# Altium schematic sheet Mainboard.SchDoc
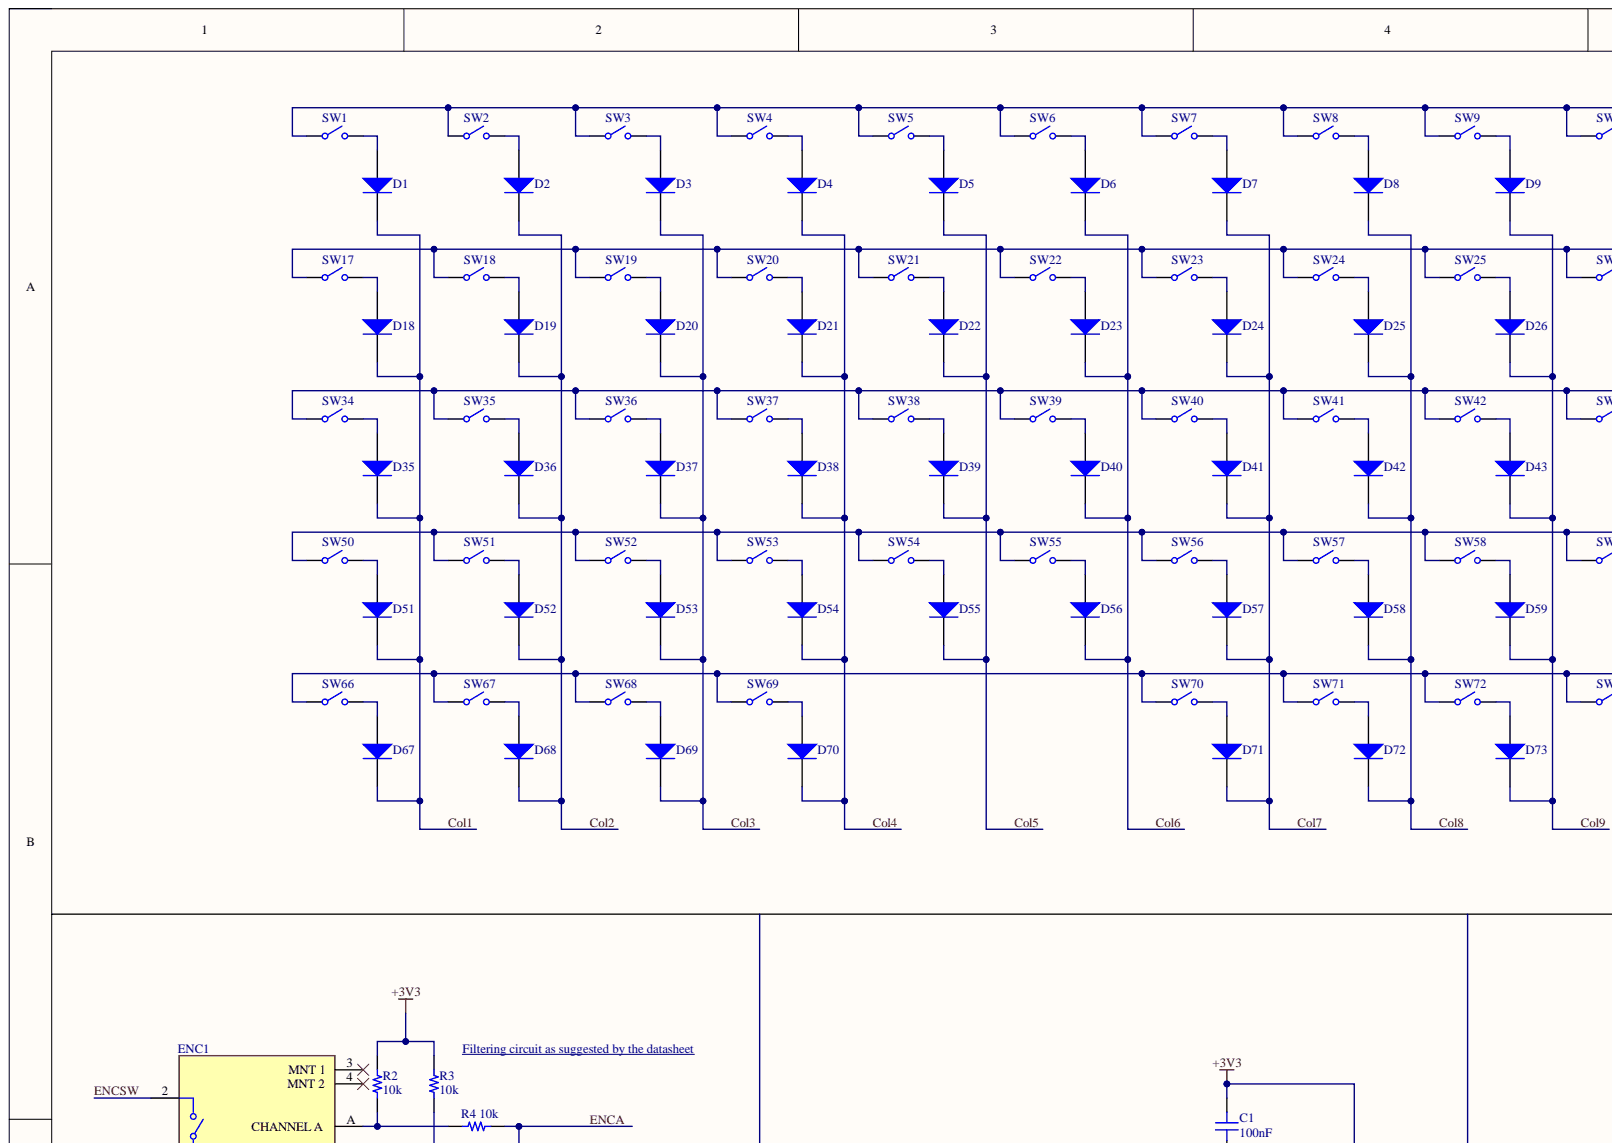
[diagram p0: Mainboard.SchDoc, top left]
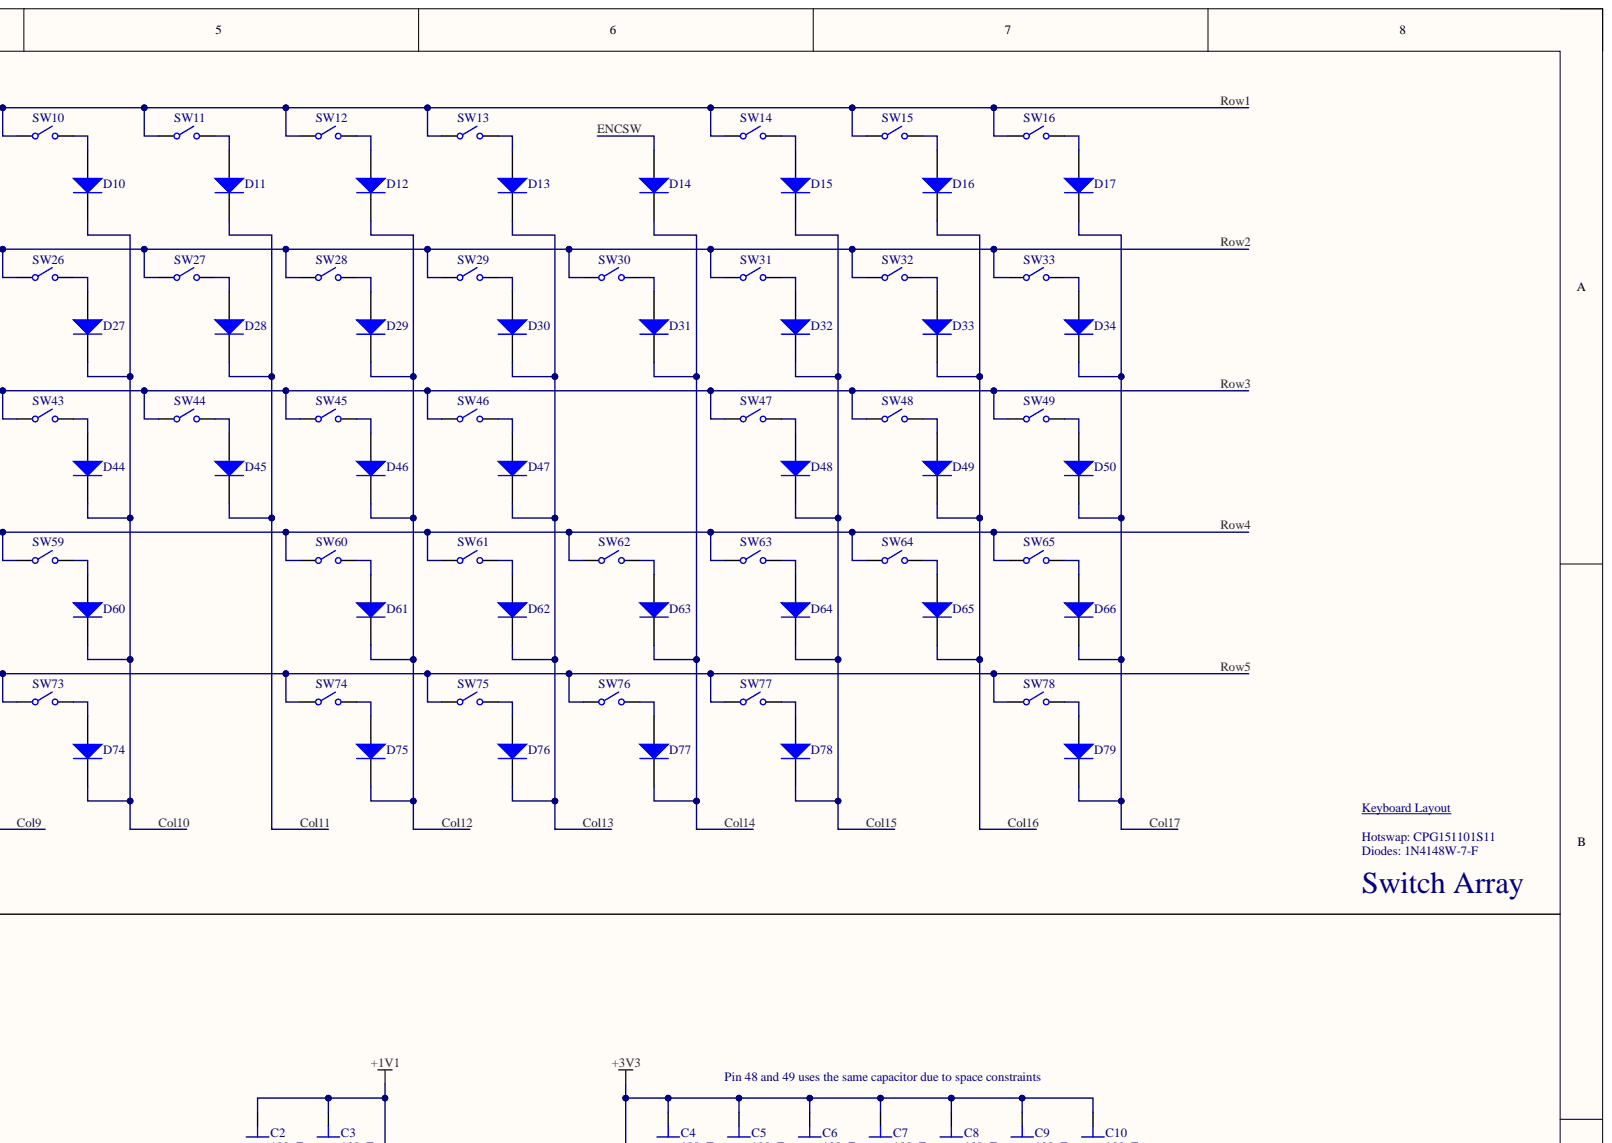
[diagram p1: Mainboard.SchDoc, top right]
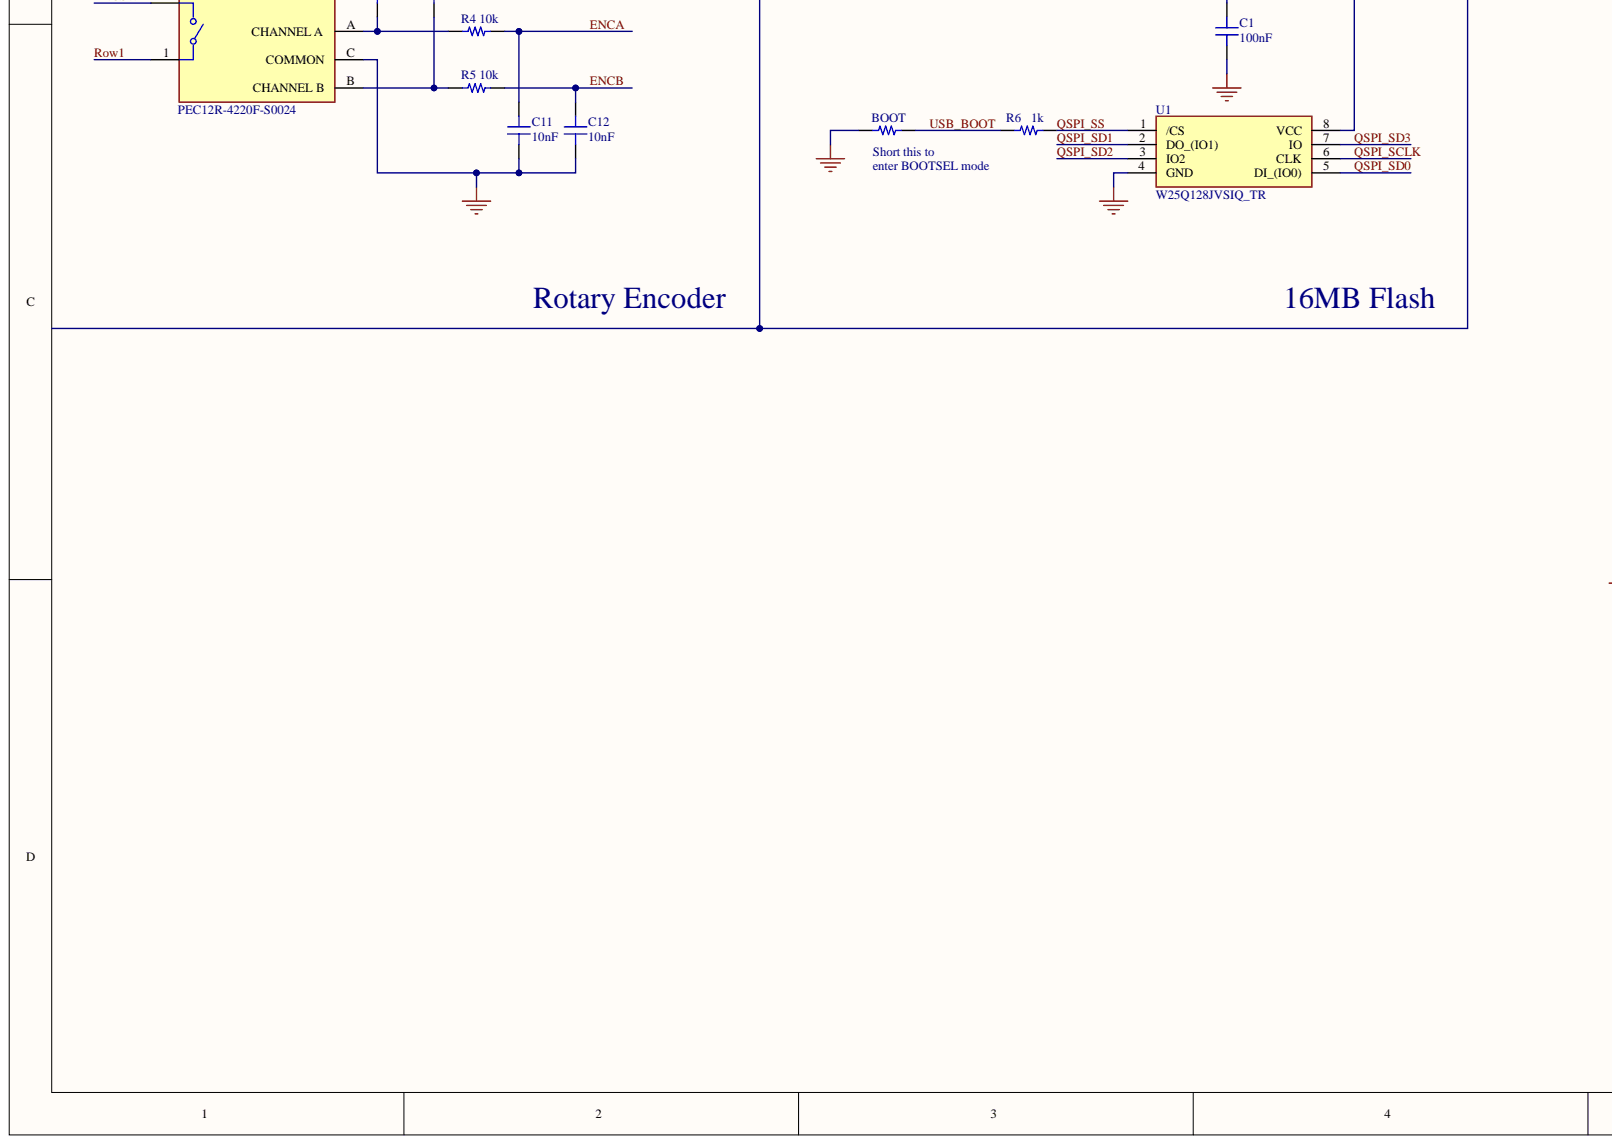
[diagram p2: Mainboard.SchDoc, bottom left]
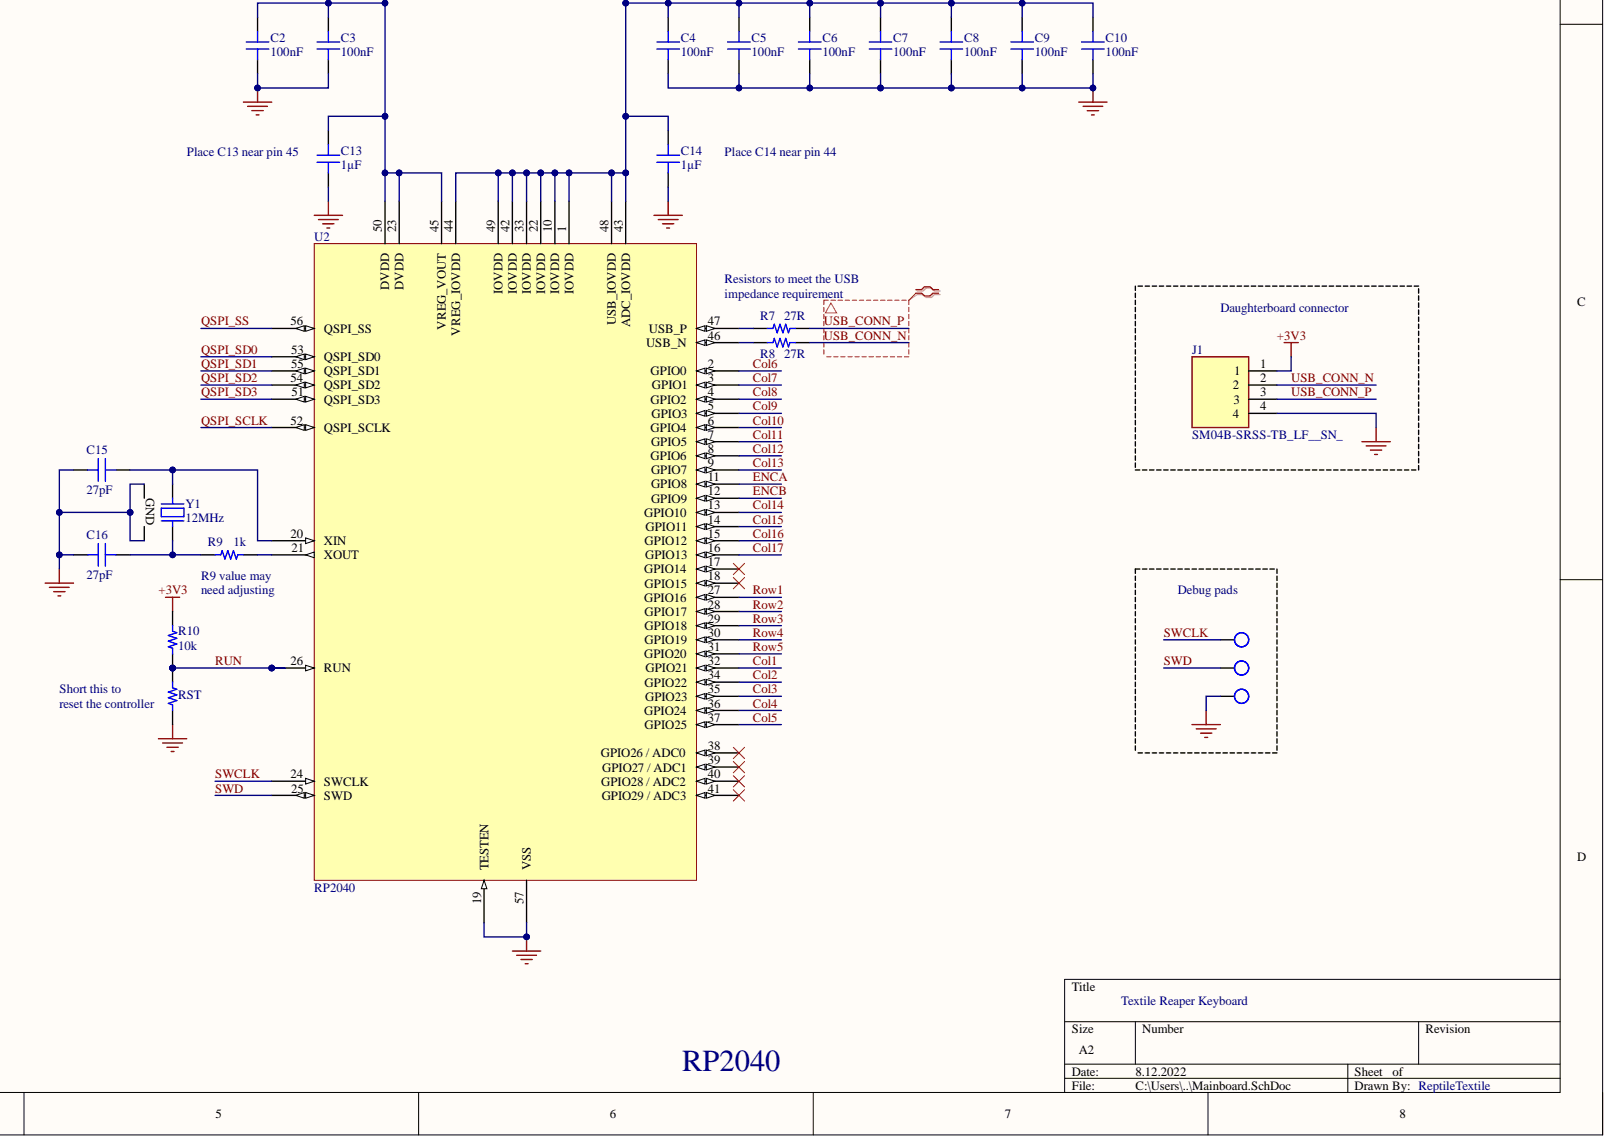
[diagram p3: Mainboard.SchDoc, bottom right]

## Parts
BOOT  0  [RES 0402_1005]  (value not drawn)
C1  100nF  [CAP 0402_1005]
C2  100nF  [CAP 0402_1005]
C3  100nF  [CAP 0402_1005]
C4  100nF  [CAP 0402_1005]
C5  100nF  [CAP 0402_1005]
C6  100nF  [CAP 0402_1005]
C7  100nF  [CAP 0402_1005]
C8  100nF  [CAP 0402_1005]
C9  100nF  [CAP 0402_1005]
C10  100nF  [CAP 0402_1005]
C11  10nF  [CAP 0402_1005]
C12  10nF  [CAP 0402_1005]
C13  1µF  [CAP 0402_1005]
C14  1µF  [CAP 0402_1005]
C15  27pF  [CAP 0402_1005]
C16  27pF  [CAP 0402_1005]
D1  1N4148W-7-F  [DIOD-SOD123-2_V]
D2  1N4148W-7-F  [DIOD-SOD123-2_V]
D3  1N4148W-7-F  [DIOD-SOD123-2_V]
D4  1N4148W-7-F  [DIOD-SOD123-2_V]
D5  1N4148W-7-F  [DIOD-SOD123-2_V]
D6  1N4148W-7-F  [DIOD-SOD123-2_V]
D7  1N4148W-7-F  [DIOD-SOD123-2_V]
D8  1N4148W-7-F  [DIOD-SOD123-2_V]
D9  1N4148W-7-F  [DIOD-SOD123-2_V]
D10  1N4148W-7-F  [DIOD-SOD123-2_V]
D11  1N4148W-7-F  [DIOD-SOD123-2_V]
D12  1N4148W-7-F  [DIOD-SOD123-2_V]
D13  1N4148W-7-F  [DIOD-SOD123-2_V]
D14  1N4148W-7-F  [DIOD-SOD123-2_V]
D15  1N4148W-7-F  [DIOD-SOD123-2_V]
D16  1N4148W-7-F  [DIOD-SOD123-2_V]
D17  1N4148W-7-F  [DIOD-SOD123-2_V]
D18  1N4148W-7-F  [DIOD-SOD123-2_V]
D19  1N4148W-7-F  [DIOD-SOD123-2_V]
D20  1N4148W-7-F  [DIOD-SOD123-2_V]
D21  1N4148W-7-F  [DIOD-SOD123-2_V]
D22  1N4148W-7-F  [DIOD-SOD123-2_V]
D23  1N4148W-7-F  [DIOD-SOD123-2_V]
D24  1N4148W-7-F  [DIOD-SOD123-2_V]
D25  1N4148W-7-F  [DIOD-SOD123-2_V]
D26  1N4148W-7-F  [DIOD-SOD123-2_V]
D27  1N4148W-7-F  [DIOD-SOD123-2_V]
D28  1N4148W-7-F  [DIOD-SOD123-2_V]
D29  1N4148W-7-F  [DIOD-SOD123-2_V]
D30  1N4148W-7-F  [DIOD-SOD123-2_V]
D31  1N4148W-7-F  [DIOD-SOD123-2_V]
D32  1N4148W-7-F  [DIOD-SOD123-2_V]
D33  1N4148W-7-F  [DIOD-SOD123-2_V]
D34  1N4148W-7-F  [DIOD-SOD123-2_V]
D35  1N4148W-7-F  [DIOD-SOD123-2_V]
D36  1N4148W-7-F  [DIOD-SOD123-2_V]
D37  1N4148W-7-F  [DIOD-SOD123-2_V]
D38  1N4148W-7-F  [DIOD-SOD123-2_V]
D39  1N4148W-7-F  [DIOD-SOD123-2_V]
D40  1N4148W-7-F  [DIOD-SOD123-2_V]
D41  1N4148W-7-F  [DIOD-SOD123-2_V]
D42  1N4148W-7-F  [DIOD-SOD123-2_V]
D43  1N4148W-7-F  [DIOD-SOD123-2_V]
D44  1N4148W-7-F  [DIOD-SOD123-2_V]
D45  1N4148W-7-F  [DIOD-SOD123-2_V]
D46  1N4148W-7-F  [DIOD-SOD123-2_V]
D47  1N4148W-7-F  [DIOD-SOD123-2_V]
D48  1N4148W-7-F  [DIOD-SOD123-2_V]
D49  1N4148W-7-F  [DIOD-SOD123-2_V]
D50  1N4148W-7-F  [DIOD-SOD123-2_V]
D51  1N4148W-7-F  [DIOD-SOD123-2_V]
D52  1N4148W-7-F  [DIOD-SOD123-2_V]
D53  1N4148W-7-F  [DIOD-SOD123-2_V]
D54  1N4148W-7-F  [DIOD-SOD123-2_V]
D55  1N4148W-7-F  [DIOD-SOD123-2_V]
D56  1N4148W-7-F  [DIOD-SOD123-2_V]
D57  1N4148W-7-F  [DIOD-SOD123-2_V]
D58  1N4148W-7-F  [DIOD-SOD123-2_V]
D59  1N4148W-7-F  [DIOD-SOD123-2_V]
D60  1N4148W-7-F  [DIOD-SOD123-2_V]
D61  1N4148W-7-F  [DIOD-SOD123-2_V]
D62  1N4148W-7-F  [DIOD-SOD123-2_V]
D63  1N4148W-7-F  [DIOD-SOD123-2_V]
D64  1N4148W-7-F  [DIOD-SOD123-2_V]
D65  1N4148W-7-F  [DIOD-SOD123-2_V]
D66  1N4148W-7-F  [DIOD-SOD123-2_V]
D67  1N4148W-7-F  [DIOD-SOD123-2_V]
D68  1N4148W-7-F  [DIOD-SOD123-2_V]
D69  1N4148W-7-F  [DIOD-SOD123-2_V]
D70  1N4148W-7-F  [DIOD-SOD123-2_V]
D71  1N4148W-7-F  [DIOD-SOD123-2_V]
D72  1N4148W-7-F  [DIOD-SOD123-2_V]
D73  1N4148W-7-F  [DIOD-SOD123-2_V]
D74  1N4148W-7-F  [DIOD-SOD123-2_V]
D75  1N4148W-7-F  [DIOD-SOD123-2_V]
D76  1N4148W-7-F  [DIOD-SOD123-2_V]
D77  1N4148W-7-F  [DIOD-SOD123-2_V]
D78  1N4148W-7-F  [DIOD-SOD123-2_V]
D79  1N4148W-7-F  [DIOD-SOD123-2_V]
ENC1  PEC12R-4220F-S0024  [FP-PEC12R-4220F-S0024-MFG]
GND  Pad  [Square_Pad]  (value not drawn)
J1  SM04B-SRSS-TB_LF__SN_  [SM04B-SRSS-TB(LFSN)]
R2  10k  [RES 0402_1005]
R3  10k  [RES 0402_1005]
R4  10k  [RES 0402_1005]
R5  10k  [RES 0402_1005]
R6  1k  [RES 0402_1005]
R7  27R  [RES 0402_1005]
R8  27R  [RES 0402_1005]
R9  1k  [RES 0402_1005]
R10  10k  [RES 0402_1005]
RST  0  [RES 0402_1005]  (value not drawn)
SW1  CPG151101S11  [CPG151101S11]
SW2  CPG151101S11  [CPG151101S11]
SW3  CPG151101S11  [CPG151101S11]
SW4  CPG151101S11  [CPG151101S11]
SW5  CPG151101S11  [CPG151101S11]
SW6  CPG151101S11  [CPG151101S11]
SW7  CPG151101S11  [CPG151101S11]
SW8  CPG151101S11  [CPG151101S11]
SW9  CPG151101S11  [CPG151101S11]
SW10  CPG151101S11  [CPG151101S11]
SW11  CPG151101S11  [CPG151101S11]
SW12  CPG151101S11  [CPG151101S11]
SW13  CPG151101S11  [CPG151101S11]
SW14  CPG151101S11  [CPG151101S11]
SW15  CPG151101S11  [CPG151101S11]
SW16  CPG151101S11  [CPG151101S11]
SW17  CPG151101S11  [CPG151101S11]
SW18  CPG151101S11  [CPG151101S11]
SW19  CPG151101S11  [CPG151101S11]
SW20  CPG151101S11  [CPG151101S11]
SW21  CPG151101S11  [CPG151101S11]
SW22  CPG151101S11  [CPG151101S11]
SW23  CPG151101S11  [CPG151101S11]
SW24  CPG151101S11  [CPG151101S11]
SW25  CPG151101S11  [CPG151101S11]
SW26  CPG151101S11  [CPG151101S11]
SW27  CPG151101S11  [CPG151101S11]
SW28  CPG151101S11  [CPG151101S11]
SW29  CPG151101S11  [CPG151101S11]
SW30  CPG151101S11  [CPG151101S11]
SW31  CPG151101S11  [CPG151101S11]
SW32  CPG151101S11  [CPG151101S11]
SW33  CPG151101S11  [CPG151101S11]
SW34  CPG151101S11  [CPG151101S11]
SW35  CPG151101S11  [CPG151101S11]
SW36  CPG151101S11  [CPG151101S11]
SW37  CPG151101S11  [CPG151101S11]
SW38  CPG151101S11  [CPG151101S11]
SW39  CPG151101S11  [CPG151101S11]
SW40  CPG151101S11  [CPG151101S11]
SW41  CPG151101S11  [CPG151101S11]
SW42  CPG151101S11  [CPG151101S11]
SW43  CPG151101S11  [CPG151101S11]
SW44  CPG151101S11  [CPG151101S11]
SW45  CPG151101S11  [CPG151101S11]
SW46  CPG151101S11  [CPG151101S11]
SW47  CPG151101S11  [CPG151101S11]
SW48  CPG151101S11  [CPG151101S11]
SW49  CPG151101S11  [CPG151101S11]
SW50  CPG151101S11  [CPG151101S11]
SW51  CPG151101S11  [CPG151101S11]
SW52  CPG151101S11  [CPG151101S11]
SW53  CPG151101S11  [CPG151101S11]
SW54  CPG151101S11  [CPG151101S11]
SW55  CPG151101S11  [CPG151101S11]
SW56  CPG151101S11  [CPG151101S11]
SW57  CPG151101S11  [CPG151101S11]
SW58  CPG151101S11  [CPG151101S11]
SW59  CPG151101S11  [CPG151101S11]
SW60  CPG151101S11  [CPG151101S11]
SW61  CPG151101S11  [CPG151101S11]
SW62  CPG151101S11  [CPG151101S11]
SW63  CPG151101S11  [CPG151101S11]
SW64  CPG151101S11  [CPG151101S11]
SW65  CPG151101S11  [CPG151101S11]
SW66  CPG151101S11  [CPG151101S11]
SW67  CPG151101S11  [CPG151101S11]
SW68  CPG151101S11  [CPG151101S11]
SW69  CPG151101S11  [CPG151101S11]
SW70  CPG151101S11  [CPG151101S11]
SW71  CPG151101S11  [CPG151101S11]
SW72  CPG151101S11  [CPG151101S11]
SW73  CPG151101S11  [CPG151101S11]
SW74  CPG151101S11  [CPG151101S11]
SW75  CPG151101S11  [CPG151101S11]
SW76  CPG151101S11  [CPG151101S11]
SW77  CPG151101S11  [CPG151101S11]
SW78  CPG151101S11  [CPG151101S11]
SWCLK  Pad  [Square_Pad]  (value not drawn)
SWD  Pad  [Square_Pad]  (value not drawn)
U1  W25Q128JVSIQ_TR  [SOIC127P780X195-8N]
U2  RP2040  [RP2040_QFN56]
Y1  12MHz  [ABRACON ABM8G]

## Nets
+1V1: C2.2 C3.2 C13.2 U2.23(DVDD) U2.45(VREG_VOUT) U2.50(DVDD)
+3V3: C1.2 C4.2 C5.2 C6.2 C7.2 C8.2 C9.2 C10.2 C14.2 J1.1 R2.1 R3.1 R10.1 U1.8(VCC) U2.1(IOVDD) U2.10(IOVDD) U2.22(IOVDD) U2.33(IOVDD) U2.42(IOVDD) U2.43(ADC_IOVDD) U2.44(VREG_IOVDD) U2.48(USB_IOVDD) U2.49(IOVDD)
GND: BOOT.1 C1.1 C2.1 C3.1 C4.1 C5.1 C6.1 C7.1 C8.1 C9.1 C10.1 C11.1 C12.1 C13.1 C14.1 C15.1 C16.1 ENC1.C(COMMON) GND.0 J1.4 RST.1 U1.4(GND) U2.19(TESTEN) U2.57(VSS) Y1.2 Y1.4
Col1: D1.2 D18.2 D35.2 D51.2 D67.2 U2.32(GPIO21)
Col2: D2.2 D19.2 D36.2 D52.2 D68.2 U2.34(GPIO22)
Col3: D3.2 D20.2 D37.2 D53.2 D69.2 U2.35(GPIO23)
Col4: D4.2 D21.2 D38.2 D54.2 D70.2 U2.36(GPIO24)
Col5: D5.2 D22.2 D39.2 D55.2 U2.37(GPIO25)
Col6: D6.2 D23.2 D40.2 D56.2 U2.2(GPIO0)
Col7: D7.2 D24.2 D41.2 D57.2 D71.2 U2.3(GPIO1)
Col8: D8.2 D25.2 D42.2 D58.2 D72.2 U2.4(GPIO2)
Col9: D9.2 D26.2 D43.2 D59.2 D73.2 U2.5(GPIO3)
Col10: D10.2 D27.2 D44.2 D60.2 D74.2 U2.6(GPIO4)
Col11: D11.2 D28.2 D45.2 U2.7(GPIO5)
Col12: D12.2 D29.2 D46.2 D61.2 D75.2 U2.8(GPIO6)
Col13: D13.2 D30.2 D47.2 D62.2 D76.2 U2.9(GPIO7)
Col14: D14.2 D31.2 D63.2 D77.2 U2.13(GPIO10)
Col15: D15.2 D32.2 D48.2 D64.2 D78.2 U2.14(GPIO11)
Col16: D16.2 D33.2 D49.2 D65.2 U2.15(GPIO12)
Col17: D17.2 D34.2 D50.2 D66.2 D79.2 U2.16(GPIO13)
ENCA: C11.2 R4.2 U2.11(GPIO8)
ENCB: C12.2 R5.2 U2.12(GPIO9)
ENCSW: D14.1 ENC1.2
QSPI_SCLK: U1.6(CLK) U2.52(QSPI_SCLK)
QSPI_SD0: U1.5(DI_(IO0)) U2.53(QSPI_SD0)
QSPI_SD1: U1.2(DO_(IO1)) U2.55(QSPI_SD1)
QSPI_SD2: U1.3(IO2) U2.54(QSPI_SD2)
QSPI_SD3: U1.7(IO) U2.51(QSPI_SD3)
QSPI_SS: R6.2 U1.1(/CS) U2.56(QSPI_SS)
Row1: ENC1.1 SW1.1 SW2.1 SW3.1 SW4.1 SW5.1 SW6.1 SW7.1 SW8.1 SW9.1 SW10.1 SW11.1 SW12.1 SW13.1 SW14.1 SW15.1 SW16.1 U2.27(GPIO16)
Row2: SW17.1 SW18.1 SW19.1 SW20.1 SW21.1 SW22.1 SW23.1 SW24.1 SW25.1 SW26.1 SW27.1 SW28.1 SW29.1 SW30.1 SW31.1 SW32.1 SW33.1 U2.28(GPIO17)
Row3: SW34.1 SW35.1 SW36.1 SW37.1 SW38.1 SW39.1 SW40.1 SW41.1 SW42.1 SW43.1 SW44.1 SW45.1 SW46.1 SW47.1 SW48.1 SW49.1 U2.29(GPIO18)
Row4: SW50.1 SW51.1 SW52.1 SW53.1 SW54.1 SW55.1 SW56.1 SW57.1 SW58.1 SW59.1 SW60.1 SW61.1 SW62.1 SW63.1 SW64.1 SW65.1 U2.30(GPIO19)
Row5: SW66.1 SW67.1 SW68.1 SW69.1 SW70.1 SW71.1 SW72.1 SW73.1 SW74.1 SW75.1 SW76.1 SW77.1 SW78.1 U2.31(GPIO20)
RUN: R10.2 RST.2 U2.26(RUN)
SWCLK: SWCLK.0 U2.24(SWCLK)
SWD: SWD.0 U2.25(SWD)
USB_BOOT: BOOT.2 R6.1
USB_CONN_N: J1.2 R8.2
USB_CONN_P: J1.3 R7.2
NetC15_2: C15.2 U2.20(XIN) Y1.1
NetC16_2: C16.2 R9.1 Y1.3
NetD1_1: D1.1 SW1.2
NetD2_1: D2.1 SW2.2
NetD3_1: D3.1 SW3.2
NetD4_1: D4.1 SW4.2
NetD5_1: D5.1 SW5.2
NetD6_1: D6.1 SW6.2
NetD7_1: D7.1 SW7.2
NetD8_1: D8.1 SW8.2
NetD9_1: D9.1 SW9.2
NetD10_1: D10.1 SW10.2
NetD11_1: D11.1 SW11.2
NetD12_1: D12.1 SW12.2
NetD13_1: D13.1 SW13.2
NetD15_1: D15.1 SW14.2
NetD16_1: D16.1 SW15.2
NetD17_1: D17.1 SW16.2
NetD18_1: D18.1 SW17.2
NetD19_1: D19.1 SW18.2
NetD20_1: D20.1 SW19.2
NetD21_1: D21.1 SW20.2
NetD22_1: D22.1 SW21.2
NetD23_1: D23.1 SW22.2
NetD24_1: D24.1 SW23.2
NetD25_1: D25.1 SW24.2
NetD26_1: D26.1 SW25.2
NetD27_1: D27.1 SW26.2
NetD28_1: D28.1 SW27.2
NetD29_1: D29.1 SW28.2
NetD30_1: D30.1 SW29.2
NetD31_1: D31.1 SW30.2
NetD32_1: D32.1 SW31.2
NetD33_1: D33.1 SW32.2
NetD34_1: D34.1 SW33.2
NetD35_1: D35.1 SW34.2
NetD36_1: D36.1 SW35.2
NetD37_1: D37.1 SW36.2
NetD38_1: D38.1 SW37.2
NetD39_1: D39.1 SW38.2
NetD40_1: D40.1 SW39.2
NetD41_1: D41.1 SW40.2
NetD42_1: D42.1 SW41.2
NetD43_1: D43.1 SW42.2
NetD44_1: D44.1 SW43.2
NetD45_1: D45.1 SW44.2
NetD46_1: D46.1 SW45.2
NetD47_1: D47.1 SW46.2
NetD48_1: D48.1 SW47.2
NetD49_1: D49.1 SW48.2
NetD50_1: D50.1 SW49.2
NetD51_1: D51.1 SW50.2
NetD52_1: D52.1 SW51.2
NetD53_1: D53.1 SW52.2
NetD54_1: D54.1 SW53.2
NetD55_1: D55.1 SW54.2
NetD56_1: D56.1 SW55.2
NetD57_1: D57.1 SW56.2
NetD58_1: D58.1 SW57.2
NetD59_1: D59.1 SW58.2
NetD60_1: D60.1 SW59.2
NetD61_1: D61.1 SW60.2
NetD62_1: D62.1 SW61.2
NetD63_1: D63.1 SW62.2
NetD64_1: D64.1 SW63.2
NetD65_1: D65.1 SW64.2
NetD66_1: D66.1 SW65.2
NetD67_1: D67.1 SW66.2
NetD68_1: D68.1 SW67.2
NetD69_1: D69.1 SW68.2
NetD70_1: D70.1 SW69.2
NetD71_1: D71.1 SW70.2
NetD72_1: D72.1 SW71.2
NetD73_1: D73.1 SW72.2
NetD74_1: D74.1 SW73.2
NetD75_1: D75.1 SW74.2
NetD76_1: D76.1 SW75.2
NetD77_1: D77.1 SW76.2
NetD78_1: D78.1 SW77.2
NetD79_1: D79.1 SW78.2
NetENC1_A: ENC1.A(CHANNEL_A) R2.2 R4.1
NetENC1_B: ENC1.B(CHANNEL_B) R3.2 R5.1
NetR7_1: R7.1 U2.47(USB_P)
NetR8_1: R8.1 U2.46(USB_N)
NetR9_2: R9.2 U2.21(XOUT)

Not connected: ENC1 3(MNT_1) 4(MNT_2); U2 17(GPIO14) 18(GPIO15) 38(GPIO26_/_ADC0) 39(GPIO27_/_ADC1) 40(GPIO28_/_ADC2) 41(GPIO29_/_ADC3)

## Library data of the parts
BOOT RST = CR0402AJ/-000GAS: RESA-AS 0402 0R JUMPER 63MW; Manufacturer: Bourns Inc.; Database Table Name: Resistors - Surface Mount - 0402; Power (Watts): 0.063W, 1/16W; Resistance: 0 Ohms; Component Kind: Standard; ComponentLink1Description: Datasheet; ComponentLink1URL: //media.digikey.com/pdf/Data%20Sheets/Bourns%20PDFs/CRyyyyA-AS_Model_DS.pdf; ComponentLink2Description: DigiKey Link; ComponentLink2URL: https://www.digikey.com/en/products/detail/bourns-inc/CR0402AJ-000GAS/10240110; Composition: Thick Film; Device Package: 0402 (1005 Metric); Features: Anti-Sulfur, Automotive AEC-Q200, Moisture Resistant; Height - Seated (Max): 0.015" (0.37mm); LastUpdated: 11.08.2022 09:07:57; Library Name: Celestial Altium Library.DbLib; Lifecycle Status: Active; Minimum Order: 1; Number of Terminations: 2; Operating Temperature: -55°C ~ 155°C; Package / Case: 0402 (1005 Metric); Packaging: CutTape; Part Number: CR0402AJ/-000GAS; Part Status: Active; PartId: 7106; Price: 0.01; Ratings: AEC-Q200; Series: CR0402A-AS; Size / Dimension: 0.039" L x 0.020" W (1.00mm x 0.50mm); Supplier 1: DigiKey; Supplier Device Package: 0402; Supplier Part Number 1: CR0402AJ/-000GASCT-ND; Tolerance: Jumper; Value: 0
C1-C10 = C0402C104K4RECAUTO: CAP CER 0402 0.1UF 16V X7R 10%; manufacturer: KEMET; Capacitance: 0.1 µF; Database Table Name: Capacitors - Ceramic - 0402; Applications: Automotive, ESD Protection; Component Kind: Standard; componentlink1description: Datasheet; componentlink1url: https://api.kemet.com/component-edge/download/datasheet/C0402C104K4RECAUTO.pdf; componentlink2description: DigiKey Link; componentlink2url: https://www.digikey.com/en/products/detail/kemet/C0402C104K4RECAUTO/8563695; Device Package: 0402 (1005 Metric); lastupdated: 11.08.2022 08:52:24; Library Name: Celestial Altium Library.DbLib; Lifecycle Status: Active; Minimum Order: 1; Mounting Type: Surface Mount, MLCC; Operating Temperature: -55°C ~ 125°C; Package / Case: 0402 (1005 Metric); packaging: TapeAndReel; Part Number: C0402C104K4RECAUTO; Part Status: Active; partid: 1731; price: 0.02; Ratings: AEC-Q200; series: ESD SMD Auto X7R; Size / Dimension: 0.039" L x 0.020" W (1.00mm x 0.50mm); Supplier 1: DigiKey; Supplier Part Number 1: 399-17167-1-ND; Temperature Coefficient: X7R; Thickness (Max): 0.022" (0.55mm); Tolerance: ±10%; Value: 100nF; Voltage - Rated: 16V
C11 C12 = C0402C103J5RECAUTO: CAP CER 0402 10NF 50V X7R 5%; manufacturer: KEMET; Database Table Name: Capacitors - Ceramic - 0402; Applications: Automotive, ESD Protection; Capacitance: 10nF; Component Kind: Standard; componentlink1description: Datasheet; componentlink1url: https://api.kemet.com/component-edge/download/datasheet/C0402C103J5RECAUTO.pdf; componentlink2description: DigiKey Link; componentlink2url: https://www.digikey.com/en/products/detail/kemet/C0402C103J5RECAUTO/8646448; Device Package: 0402 (1005 Metric); lastupdated: 9.08.2022 12:00:55; Library Name: Celestial Altium Library.DbLib; Lifecycle Status: Active; Minimum Order: 1; Mounting Type: Surface Mount, MLCC; Operating Temperature: -55°C ~ 125°C; Package / Case: 0402 (1005 Metric); packaging: TapeAndReel; Part Number: C0402C103J5RECAUTO; Part Status: Active; partid: 33153; price: 0.02; Ratings: AEC-Q200; series: ESD SMD Auto X7R; Size / Dimension: 0.039" L x 0.020" W (1.00mm x 0.50mm); Supplier 1: DigiKey; Supplier Part Number 1: 399-C0402C103J5RECAUTOCT-ND; Temperature Coefficient: X7R; Thickness (Max): 0.022" (0.55mm); Tolerance: ±5%; Value: 10nF; Voltage - Rated: 50V
C13 C14 = GRM155C71A105KE11D: CAP CER 1UF 10V X7S 0402; manufacturer: Murata Electronics; Database Table Name: Capacitors - Ceramic - 0402; Tolerance: ±10%; Applications: General Purpose; Capacitance: 1 µF; Component Kind: Standard; componentlink1description: Datasheet; componentlink1url: https://search.murata.co.jp/Ceramy/image/img/A01X/G101/ENG/GRM155C71A105KE11-01.pdf; componentlink2description: DigiKey Link; componentlink2url: https://www.digikey.com/en/products/detail/murata-electronics/GRM155C71A105KE11D/6606086; Device Package: 0402 (1005 Metric); lastupdated: 11.08.2022 08:52:24; Library Name: Celestial Altium Library.DbLib; Lifecycle Status: Active; Minimum Order: 1; Mounting Type: Surface Mount, MLCC; Operating Temperature: -55°C ~ 125°C; Package / Case: 0402 (1005 Metric); packaging: TapeAndReel; Part Number: GRM155C71A105KE11D; Part Status: Active; partid: 1749; price: 0.01; series: GRM; Size / Dimension: 0.039" L x 0.020" W (1.00mm x 0.50mm); Supplier 1: DigiKey; Supplier Part Number 1: 490-14457-1-ND; Temperature Coefficient: X7S; Thickness (Max): 0.024" (0.60mm); Value: 1µF; Voltage - Rated: 10V
C15 C16 = C0402C270J3GAC7867: CAP CER 27PF 25V NP0 0402; manufacturer: KEMET; Database Table Name: Capacitors - Ceramic - 0402; Temperature Coefficient: C0G, NP0; Tolerance: ±5%; Applications: General Purpose; Capacitance: 27 pF; Component Kind: Standard; componentlink1description: Datasheet; componentlink1url: https://api.kemet.com/component-edge/download/datasheet/C0402C270J3GACTU.pdf; componentlink2description: DigiKey Link; componentlink2url: https://www.digikey.com/en/products/detail/kemet/C0402C270J3GAC7867/2196521; Device Package: 0402 (1005 Metric); Features: Low ESL; lastupdated: 11.08.2022 08:52:24; Library Name: Celestial Altium Library.DbLib; Lifecycle Status: Active; Minimum Order: 1; Mounting Type: Surface Mount, MLCC; Operating Temperature: -55°C ~ 125°C; Package / Case: 0402 (1005 Metric); packaging: TapeAndReel; Part Number: C0402C270J3GAC7867; Part Status: Active; partid: 1740; price: 0.06; series: SMD Comm C0G; Size / Dimension: 0.039" L x 0.020" W (1.00mm x 0.50mm); Supplier 1: DigiKey; Supplier Part Number 1: 399-14437-1-ND; Thickness (Max): 0.022" (0.55mm); Value: 27pF; Voltage - Rated: 25V
D1-D79 = CMP-2000-04932-2: Fast Switching Diode, 100 V, 0.15 A, -65 to 150 degC, 2-Pin?SOD123, RoHS, Tape and Reel; Brand: DiodesIncorporated; Configuration: Single; Max Surge Current: 2A; Peak Reverse Voltage: 100V; Recovery Time: 4ns; Unit Weight: 0.000353oz; Vf - Forward Voltage: 1.25V; If - Forward Current: 0.15A; Ir - Reverse Current: 1uA; Component Kind: Standard; Datasheet URL: http://www.farnell.com/datasheets/1915529.pdf; Datasheet Version: Rev. 24-2, 10/2013; Factory Pack Quantity: 3000; Height: 1.25mm; Length: 2.85mm; Library Name: Altium Content Vault; Manufacturer: DiodesIncorporated; Manufacturer URL: http://www.diodes.com/; Maximum Operating Temperature: +150C; Minimum Operating Temperature: -65C; Mounting Style: SMD/SMT; Operating Temperature Range: -65Cto+150C; Package / Case: SOD-123; Package Reference: SOD123-2; Package URL: http://www.diodes.com/datasheets/ds18004.pdf; Packaging: Reel; Product: SwitchingDiodes; Product Category: Diodes-GeneralPurpose,Power,Switching; RoHS: True; Series: 1N4148; Type: SwitchingDiode; Width: 1.7mm
ENC1 = CMP-07421-000021-1: ROTARY ENCODER MECHANICAL 24PPR; Built in Switch: Yes; Hole Diameter: 2mm; Max Supply Voltage (DC): 5V; Number of Channels: 2; Output Type: Quadrature; Pulses per Revolution: 24; Component Kind: Standard; Detent: Yes; Encoder Type: Mechanical; Height: 13.4mm; Length: 26mm; Library Name: Altium Content Vault; Max Operating Temperature: 70°C; Min Operating Temperature: -30°C; Mount: Through Hole; Orientation: Vertical; Package Quantity: 150; Packaging: Bulk; REACH SVHC: No SVHC; RoHS Compliant: Yes; Series: PEC12R; Termination: PC Pin; Width: 12.5mm
J1 = SM04B-SRSS-TB_LF__SN_: Connector; Manufacturer_Name: JST (JAPAN SOLDERLESS TERMINALS); Manufacturer_Part_Number: SM04B-SRSS-TB(LF)(SN); Arrow Part Number: SM04B-SRSS-TB(LF)(SN); Arrow Price/Stock: https://www.arrow.com/en/products/sm04b-srss-tb-lf-sn/jst-manufacturing; Component Kind: Standard; Datasheet Link: http://www.jst-mfg.com/product/pdf/eng/eSH.pdf; Library Name: SamacSys.SchLib
R2-R5 R10 = TNPW040210K0BETD: RES 10K OHM 0.1% 1/16W 0402; Manufacturer: Vishay Dale; Database Table Name: Resistors - Surface Mount - 0402; Power (Watts): 0.063W, 1/16W; Resistance: 10 kOhms; Temperature Coefficient: ±25ppm/°C; Component Kind: Standard; ComponentLink1Description: Datasheet; ComponentLink1URL: http://www.vishay.com/docs/31006/tnpw.pdf; ComponentLink2Description: DigiKey Link; ComponentLink2URL: https://www.digikey.com/en/products/detail/vishay-dale/TNPW040210K0BETD/1613222; Composition: Thin Film; Device Package: 0402 (1005 Metric); Features: Military; Height - Seated (Max): 0.016" (0.40mm); LastUpdated: 11.08.2022 09:08:10; Library Name: Celestial Altium Library.DbLib; Lifecycle Status: Active; Minimum Order: 1; Number of Terminations: 2; Operating Temperature: -55°C ~ 125°C; Package / Case: 0402 (1005 Metric); Packaging: TapeAndReel; Part Number: TNPW040210K0BETD; Part Status: Active; PartId: 3021; Price: 0.26; Series: TNPW; Size / Dimension: 0.039" L x 0.020" W (1.00mm x 0.50mm); Supplier 1: DigiKey; Supplier Device Package: 0402; Supplier Part Number 1: 541-3559-1-ND; Tolerance: ±0.1%; Value: 10k
R6 R9 = AT0402BRD071KL: RES SMD 1K OHM 0.1% 1/16W 0402; Manufacturer: Yageo; Database Table Name: Resistors - Surface Mount - 0402; Power (Watts): 0.063W, 1/16W; Resistance: 1 kOhms; Temperature Coefficient: ±25ppm/°C; Component Kind: Standard; ComponentLink1Description: Datasheet; ComponentLink1URL: http://www.yageo.com/documents/recent/PYu-AT_51_RoHS_L_5.pdf; ComponentLink2Description: DigiKey Link; ComponentLink2URL: https://www.digikey.com/en/products/detail/yageo/AT0402BRD071KL/5138607; Composition: Thin Film; Device Package: 0402 (1005 Metric); Features: Anti-Sulfur, Automotive AEC-Q200, Moisture Resistant; Height - Seated (Max): 0.014" (0.35mm); LastUpdated: 11.08.2022 09:08:11; Library Name: Celestial Altium Library.DbLib; Lifecycle Status: Active; Minimum Order: 1; Number of Terminations: 2; Operating Temperature: -55°C ~ 155°C; Package / Case: 0402 (1005 Metric); Packaging: TapeAndReel; Part Number: AT0402BRD071KL; Part Status: Active; PartId: 2462; Price: 0.09; Ratings: AEC-Q200; Series: AT; Size / Dimension: 0.039" L x 0.020" W (1.00mm x 0.50mm); Supplier 1: DigiKey; Supplier Device Package: 0402; Supplier Part Number 1: YAG2088CT-ND; Tolerance: ±0.1%; Value: 1k
R7 R8 = RC0402FR-0727RL: RES 27 OHM 1% 1/16W 0402; Manufacturer: Yageo; Database Table Name: Resistors - Surface Mount - 0402; Power (Watts): 0.063W, 1/16W; Resistance: 27 Ohms; Temperature Coefficient: ±100ppm/°C; Component Kind: Standard; ComponentLink1Description: Datasheet; ComponentLink1URL: https://www.yageo.com/upload/media/product/productsearch/datasheet/rchip/PYu-RC_Group_51_RoHS_L_10.pdf; ComponentLink2Description: DigiKey Link; ComponentLink2URL: https://www.digikey.com/en/products/detail/yageo/RC0402FR-0727RL/5280946; Composition: Thick Film; Device Package: 0402 (1005 Metric); Features: Moisture Resistant; Height - Seated (Max): 0.016" (0.40mm); LastUpdated: 11.08.2022 09:08:11; Library Name: Celestial Altium Library.DbLib; Lifecycle Status: Active; Minimum Order: 1; Number of Terminations: 2; Operating Temperature: -55°C ~ 155°C; Package / Case: 0402 (1005 Metric); Packaging: CutTape; Part Number: RC0402FR-0727RL; Part Status: Active; PartId: 2558; Price: 0.01; Series: RC_L; Size / Dimension: 0.039" L x 0.020" W (1.00mm x 0.50mm); Supplier 1: DigiKey; Supplier Device Package: 0402; Supplier Part Number 1: YAG3086CT-ND; Tolerance: ±1%; Value: 27R
SW1-SW78 = CPG151101S11 - Kailh Hotswap Socket: Component Kind: Standard; Library Name: OnatLib.IntLib
U1 = W25Q128JVSIQ_TR: Inductor; Manufacturer_Name: Winbond; Manufacturer_Part_Number: W25Q128JVSIQ TR; Arrow Part Number: W25Q128JVSIQ TR; Arrow Price/Stock: https://www.arrow.com/en/products/w25q128jvsiqtr/winbond-electronics?region=nac; Component Kind: Standard; Datasheet Link: http://www.winbond.com/resource-files/w25q128jv%20spi%20revc%2011162016.pdf; Height: 1.95mm; Mouser Part Number: 454-W25Q128JVSIQTR; Mouser Price/Stock: https://www.mouser.co.uk/ProductDetail/Winbond/W25Q128JVSIQ-TR?qs=qSfuJ%252Bfl%2Fd7vTyLA9kNdGw%3D%3D
U2 = RP2040: Raspberry Pi RP2040 Mcu, 32 Bit, 133MHZ, QFN-56;; Component Kind: Standard; Library Name: OnatLib.IntLib
Y1 = ABM8G-12.000MHZ-18-D2Y-T: CRYSTAL 12.0000MHZ 18PF SMD; Manufacturer: Abracon LLC; Database Table Name: Oscillators - Crystals; ESR (Equivalent Series Resistance): 120 Ohms; Frequency Stability: ±30ppm; Frequency Tolerance: ±20ppm; Operating Mode: Fundamental; Component Kind: Standard; ComponentLink1Description: Datasheet; ComponentLink1URL: https://abracon.com/Resonators/ABM8G.pdf; ComponentLink2Description: DigiKey Link; ComponentLink2URL: http://digikey.com/product-detail/en/abracon-llc/ABM8G-12.000MHZ-18-D2Y-T/535-10261-1-ND/2218074; Device Package: 4-SMD, No Lead; Frequency: 12MHz; Height - Seated (Max): 0.039" (1.00mm); LastUpdated: 19.03.2020 22:36:25; Library Name: Celestial Altium Library.DbLib; Lifecycle Status: Active; Load Capacitance: 18pF; Minimum Order: 1; Mounting Type: Surface Mount; Operating Temperature: -40°C ~ 85°C; Package / Case: 4-SMD, No Lead; Packaging: TapeAndReel; Part Number: ABM8G-12.000MHZ-18-D2Y-T; Part Status: Active; PartId: 310; Price: 0.59; Series: ABM8G; Size / Dimension: 0.126" L x 0.098" W (3.20mm x 2.50mm); Supplier 1: DigiKey; Supplier Part Number 1: 535-10261-1-ND; Type: MHz Crystal; Value: 12MHz
GND SWCLK SWD = Pad: Component Kind: Standard; Library Name: OnatLib.IntLib
